# Altium schematic sheet Digital-WeighingScale.SchDoc
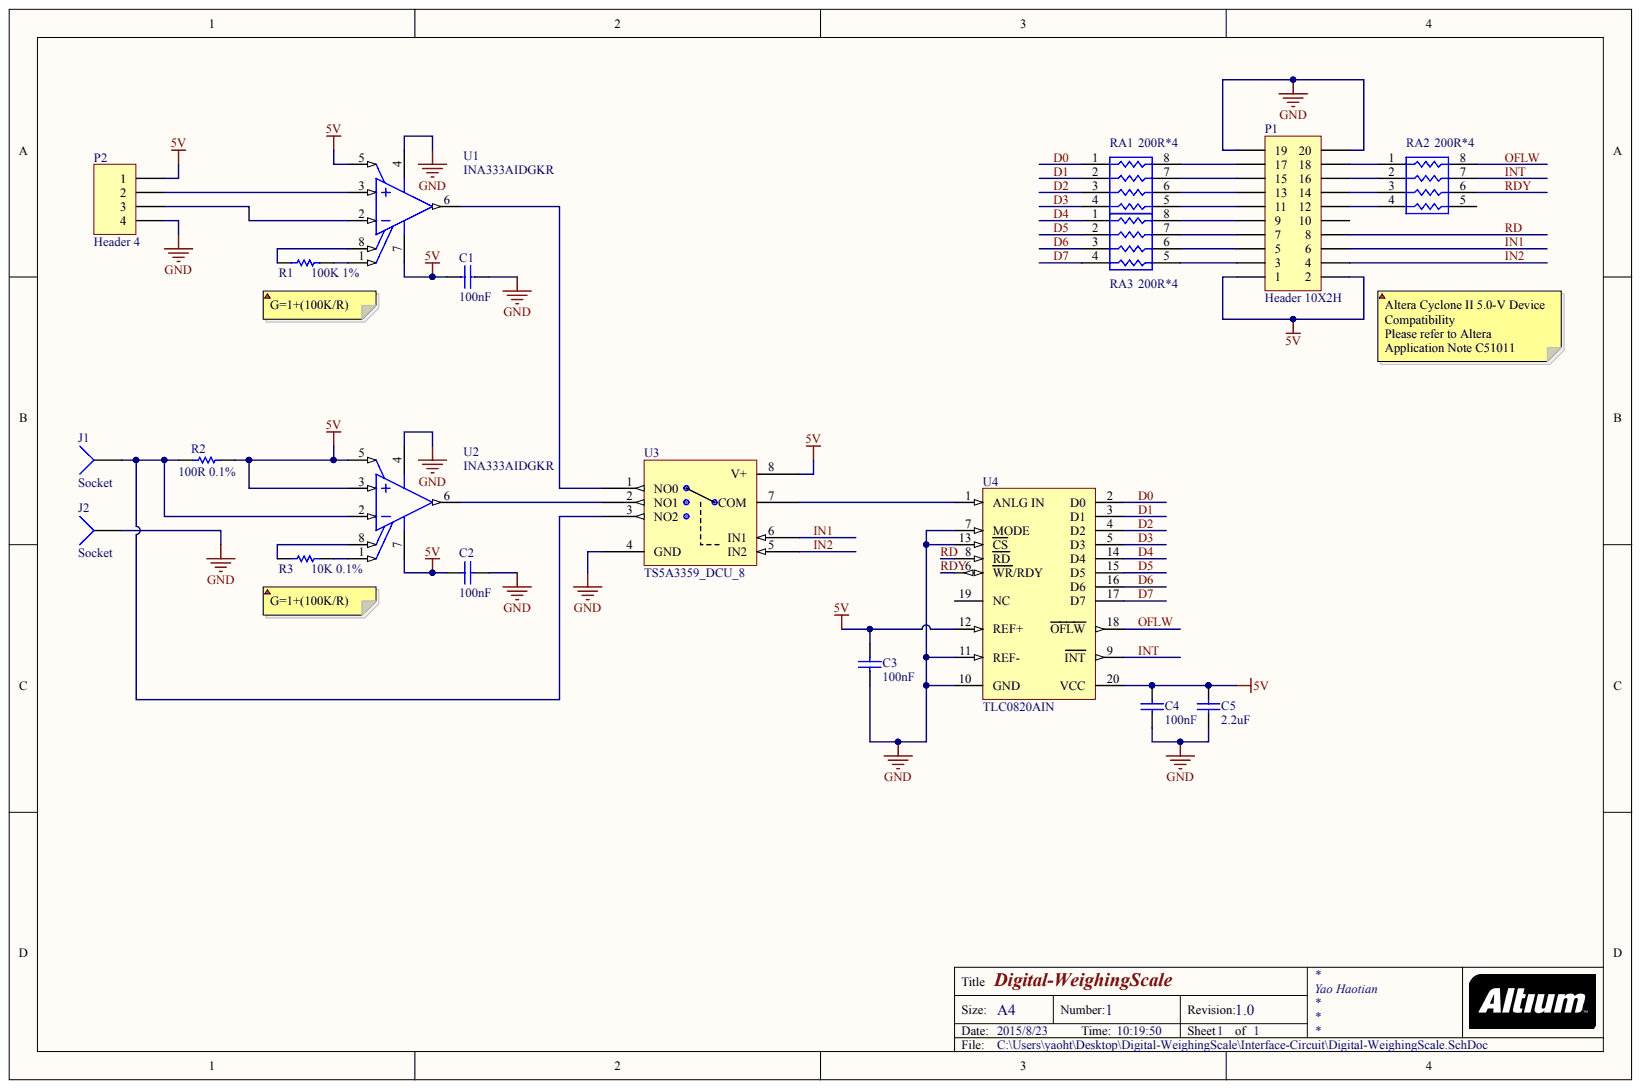
[diagram p0: Digital-WeighingScale.SchDoc]

## Parts
C1  100nF  [CAPC0603(1608)100_L]
C2  100nF  [CAPC0603(1608)100_L]
C3  100nF  [CAPC0603(1608)100_L]
C4  100nF  [CAPC0603(1608)100_L]
C5  2.2uF  [CAPC0603(1608)55_L]
J1  Socket  [PIN1]
J2  Socket  [PIN1]
P1  Header 10X2H  [HDR2X10H]
P2  Header 4  [HDR1X4]
R1  100K 1%  [RESC0603(1608)_L]
R2  100R 0.1%  [RESC0603(1608)_L]
R3  10K 0.1%  [RESC0603(1608)_L]
RA1  200R*4  [EXBV8V]
RA2  200R*4  [EXBV8V]
RA3  200R*4  [EXBV8V]
U1  INA333AIDGKR  [TI-DGK8_L]
U2  INA333AIDGKR  [TI-DGK8_L]
U3  TS5A3359_DCU_8  [DCU8]
U4  TLC0820AIN  [TI-N20]

## Nets
5V: C1.2 C2.2 C3.1 C4.1 C5.2 P1.1 P1.2 P2.1 R2.2 U1.5 U1.7 U2.3 U2.5 U2.7 U3.8(V+) U4.12(REF+) U4.20(VCC)
GND: C1.1 C2.1 C3.2 C4.2 C5.1 J2.1 P1.19 P1.20 P2.4 U1.4 U2.4 U3.4(GND) U4.7(MODE) U4.10(GND) U4.11(REF-) U4.13(CS)
D0: RA1.1 U4.2(D0)
D1: RA1.2 U4.3(D1)
D2: RA1.3 U4.4(D2)
D3: RA1.4 U4.5(D3)
D4: RA3.1 U4.14(D4)
D5: RA3.2 U4.15(D5)
D6: RA3.3 U4.16(D6)
D7: RA3.4 U4.17(D7)
IN1: P1.6 U3.6(IN1)
IN2: P1.4 U3.5(IN2)
INT: RA2.7 U4.9(INT)
OFLW: RA2.8 U4.18(OFLW)
RD: P1.8 U4.8(RD)
RDY: RA2.6 U4.6(WR/RDY)
NetJ1_1: J1.1 R2.1 U2.2 U3.3(NO2)
NetP1_3: P1.3 RA3.5
NetP1_5: P1.5 RA3.6
NetP1_7: P1.7 RA3.7
NetP1_9: P1.9 RA3.8
NetP1_11: P1.11 RA1.5
NetP1_12: P1.12 RA2.4
NetP1_13: P1.13 RA1.6
NetP1_14: P1.14 RA2.3
NetP1_15: P1.15 RA1.7
NetP1_16: P1.16 RA2.2
NetP1_17: P1.17 RA1.8
NetP1_18: P1.18 RA2.1
NetP2_2: P2.2 U1.3
NetP2_3: P2.3 U1.2
NetR1_1: R1.1 U1.8
NetR1_2: R1.2 U1.1
NetR3_1: R3.1 U2.8
NetR3_2: R3.2 U2.1
NetU1_6: U1.6 U3.1(NO0)
NetU2_6: U2.6 U3.2(NO1)
NetU3_7: U3.7(COM) U4.1(ANLG_IN)

Not connected: P1 10; RA2 5; U4 19(NC)

## Library data of the parts
C1-C4 = CMP-1035-02583-1: CAP 100nF 16V Â±5% 0603 (1608 Metric) Thickness 1mm SMD; Case-EIA: 0603; Case-Metric: 1608; Component Kind: Standard; Library Name: Altium Content Vault; Max Thickness: 1 mm; Rated Voltage: 16 V; Technology: SMT; Tolerance: Â±5%; Value: 100nF
C5 = CMP-1035-02762-1: CAP 2.2uF 16V Â±10% 0603 (1608 Metric) Thickness 0.55mm SMD; Case-EIA: 0603; Case-Metric: 1608; Component Kind: Standard; Library Name: Altium Content Vault; Max Thickness: 0.55 mm; Rated Voltage: 16 V; Technology: SMT; Tolerance: Â±10%; Value: 2.2uF
P1 = Header 10X2H: Header, 10-Pin, Dual row, Right Angle; Component Kind: Standard; LatestRevisionDate: 17-Jul-2002; LatestRevisionNote: Re-released for DXP Platform.; Library Name: Miscellaneous Connectors.IntLib; Publisher: Altium Limited
P2 = Header 4: Header, 4-Pin; Component Kind: Standard; LatestRevisionDate: 17-Jul-2002; LatestRevisionNote: Re-released for DXP Platform.; Library Name: Miscellaneous Connectors.IntLib; Publisher: Altium Limited
R1 R3 = CMP-1012-00736-1: 100K 0.1W 1% 0603 (1608 Metric)  SMD; Case-EIA: 0603; Case-Metric: 1608; Value: 100000.00 Ohm; Component Kind: Standard; Library Name: Altium Content Vault; Power: 0.1 W; Technology: SMT; Tolerance: 1 %
R2 = CMP-1012-00510-1: 1K 0.1W 1% 0603 (1608 Metric)  SMD; Case-EIA: 0603; Case-Metric: 1608; Value: 1000.00 Ohm; Component Kind: Standard; Library Name: Altium Content Vault; Power: 0.1 W; Technology: SMT; Tolerance: 0.1 %
RA1-RA3 = Res Pack: Isolated Resistor Network; Code_IPC: SOIC127P600-16; Code_JEDEC: MS-012-AC; Component Kind: Standard; LatestRevisionDate: 29-May-2009; LatestRevisionNote: IPC-7351 Footprint Added.; Library Name: Schlib.SchLib; PackageDescription: 16-Pin Small Outline Integrated Circuit 1.27 mm Pitch; PackageReference: SO-16; Published: 24-Mar-1999; Publisher: Altium Limited
U1 U2 = CMP-0944-00088-1: Low Power, Precision Instrumentation Amplifier, -40 to 125 degC, 8-pin SOP (DGK8), Green (RoHS & no Sb/Br); Manufacturer: Texas Instruments; CMRR(Min)(dB): 100; Gain(V/V): 1 to 1000; Input Bias Current (+/-)(Max)(nA): 0.2; Input Offset (+/-)(Max)(uV): 25; Input Offset Drift (+/-)(Max)(uV/Degrees Celsius): 0.1; Noise at 1kHz(Typ)(nV/rt(Hz)): 50; Number of Channels: 1; Output Offset (+/-)(Max)(uV): 75/G; Output Offset Drift (+/-)(Max)(uV/Degrees Celsius): 0.5/G; Quiescent Current (+/-)(Typ)(mA): 0.05; SubFamily: Single Supply; Vs(Max)(V): 5.5; Vs(Min)(V): 1.8; Code_JEDEC: MO-187; Component Kind: Standard; ComponentLink1Description: Manufacturer URL; ComponentLink1URL: http://www.ti.com/; ComponentLink2Description: Datasheet; ComponentLink2URL: http://www.ti.com/general/docs/lit/getliterature.tsp?genericPartNumber=INA333&fileType=pdf; ComponentLink3Description: Package Specification; ComponentLink3URL: http://www.ti.com/litv/pdf/mpds028d; DatasheetVersion: SBOS445B; Eco-Plan: Green (RoHS & no Sb/Br); Library Name: Altium Content Vault; Mounting Technology: Surface Mount; PackageDescription: 8-Pin Thin Small Outline, Body 3 x 3 mm, Pitch 0.65 mm; PackageReference: DGK8; PackageVersion: 4073329/E, 05/2006; PartNumber: INA333AIDGKR
U3 = TS5A3359_DCU_8: Imported; Manufacturer: Texas Instruments; FAMILY_NAME: ANALOG SWITCH OR MULTIPLEXER (less than or equal to 5V); INDUSTRY_STD_PKG_TYPE: US8; PACKAGE_DESIGNATOR: DCU; PIN_COUNT: 8; Component Kind: Standard; Datasheet URL: http://www.ti.com/lit/gpn/TS5A3359; GENERIC_PART_NUMBER: TS5A3359; Library Name: Schlib.SchLib; Manufacturer_Part_Number: ts5a3359_dcu_8; RefDes: RefDes; Type: DEV; Value: Value; Vendor: Texas Instruments
U4 = CMP-0003-01709-1: 8-Bit, 392 kSPS ADC Parallel Out, Microprocessor Peripheral, On-Chip Track-and-Hold, Single Channel, -40 to 85 degC, 20-pin DIP (N20), Pb-Free (RoHS); Manufacturer: Texas Instruments; # Input Channels: 1; Analog Voltage AV/DD(Max)(V): 8; Analog Voltage AV/DD(Min)(V): 4.5; Architecture: SAR; Interface: Parallel CMOS; Sample Rate (max)(SPS): 392k; SubFamily: Precision ADC (<=10MSPS); Code_JEDEC: MS-001; Component Kind: Standard; ComponentLink1Description: Manufacturer URL; ComponentLink1URL: http://www.ti.com/; ComponentLink2Description: Datasheet; ComponentLink2URL: http://www.ti.com/general/docs/lit/getliterature.tsp?genericPartNumber=TLC0820A&fileType=pdf; ComponentLink3Description: Package Specification; ComponentLink3URL: http://www.ti.com/lit/ml/mpdi002c/mpdi002c.pdf; DatasheetVersion: SLAS064A; Digital Supply(Max)(V): 8; Digital Supply(Min)(V): 4.5; Eco-Plan: Pb-Free (RoHS); Library Name: Altium Content Vault; Mounting Technology: Through Hole; PackageDescription: 20-Pin Plastic In-Line, Body 25.40 x 6.35 mm, Pitch 2.54 mm; PackageReference: N20; PackageVersion: 4040049/E, 12/2002; PartNumber: TLC0820AIN; Resolution(Bits): 8
J1 J2 = Socket: Component Kind: Standard; Description: Socket; LatestRevisionDate: 17-Jul-2002; LatestRevisionNote: Re-released for DXP Platform.; Library Name: Miscellaneous Connectors.IntLib; Publisher: Altium Limited
